# Altium schematic sheet Sheet1.SchDoc
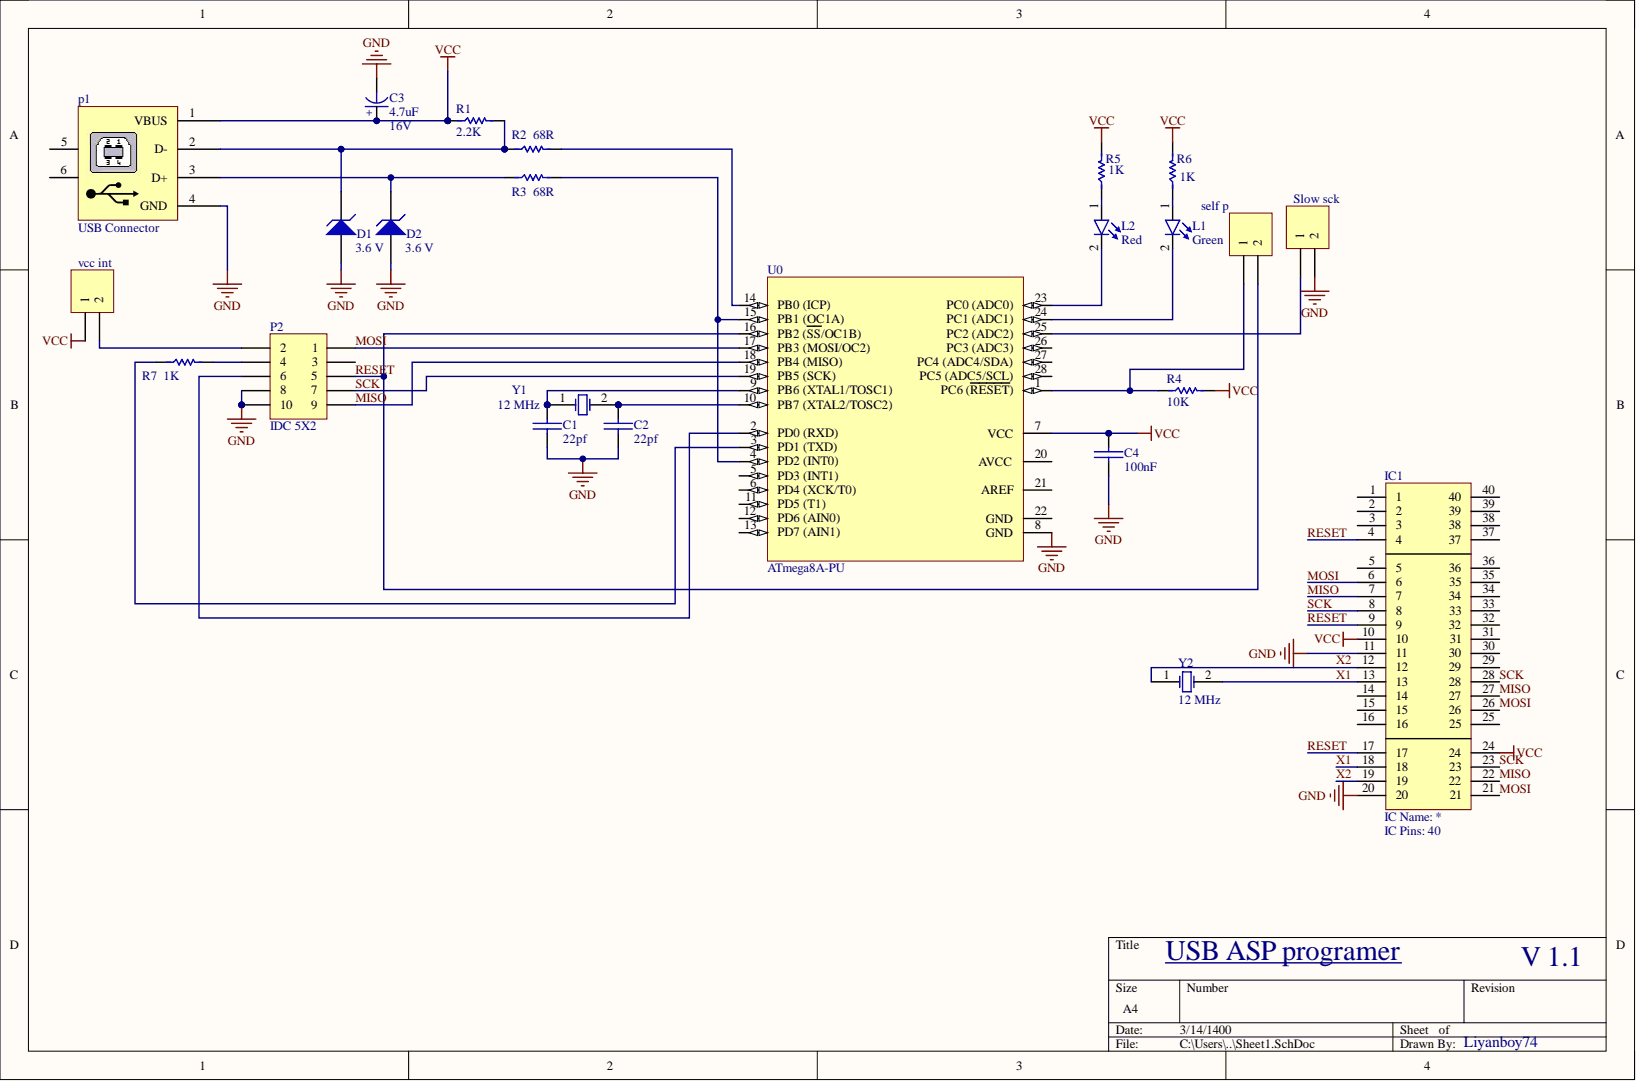
[diagram p0: Sheet1.SchDoc]

## Parts
C1  22pf  [Cap - 100nF]
C2  22pf  [Cap - 100nF]
C3  4.7uF  Voltage: 16V  [cap 03]
C4  100nF  [Cap - 100nF]
D1  Diode Zener  [Diode 1W]  (value not drawn)
D2  Diode Zener  [Diode 1W]  (value not drawn)
IC1  Zip Socket  [DIP40 - Zip Socket]  (value not drawn)
L1  Green  [LED-5mm]
L2  Red  [LED-5mm]
p1  USB Connector  [USB2.5-2H4E]
P2  IDC 5X2  [IDC2.54-H10C]
R1  2.2K  [Res-(1/4)]
R2  68R  [Res-(1/4)]
R3  68R  [Res-(1/4)]
R4  10K  [Res-(1/4)]
R5  1K  [Res-(1/4)]
R6  1K  [Res-(1/4)]
R7  1K  [Res-(1/4)]
self_p  Pin Header  [Jumper Small - 2.54 - BLUE]  (value not drawn)
Slow_sck  Pin Header  [Jumper Small - 2.54 - BLUE]  (value not drawn)
U0  ATmega8A-PU  [28P3]
vcc_int  Pin Header  [Jumper Small - 2.54 - BLUE]  (value not drawn)
Y1  12 MHz  [XTAL Small]
Y2  12 MHz  [XTAL Small]

## Nets
GND: C1.2 C2.2 C3.2 C4.2 D1.1 D2.1 IC1.11 IC1.20 p1.4(GND) P2.8 P2.10 Slow_sck.2 U0.8(GND)
VCC: C3.1 C4.1 IC1.10 IC1.24 p1.1(VBUS) R1.1 R4.2 R5.2 R6.2 U0.7(VCC) vcc_int.1
MISO: IC1.7 IC1.22 IC1.27 P2.9 U0.18(PB4_(MISO))
MOSI: IC1.6 IC1.21 IC1.26 P2.1 U0.17(PB3_(MOSI/OC2))
RESET: IC1.4 IC1.9 IC1.17 P2.5 self_p.2 U0.16(PB2_(SS/OC1B))
SCK: IC1.8 IC1.23 IC1.28 P2.7 U0.19(PB5_(SCK))
X1: IC1.13 IC1.18 Y2.2
X2: IC1.12 IC1.19 Y2.1
NetC1_1: C1.1 U0.9(PB6_(XTAL1/TOSC1)) Y1.1
NetC2_1: C2.1 U0.10(PB7_(XTAL2/TOSC2)) Y1.2
NetD1_2: D1.2 p1.2(D-) R1.2 R2.1
NetD2_2: D2.2 p1.3(D+) R3.1
NetL1_1: L1.1 R6.1
NetL1_2: L1.2 U0.24(PC1_(ADC1))
NetL2_1: L2.1 R5.1
NetL2_2: L2.2 U0.23(PC0_(ADC0))
Netp1_5: p1.5 P2.2 P2.3 P2.4 P2.6 R7.2 U0.2(PD0_(RXD)) vcc_int.2
NetR2_2: R2.2 U0.14(PB0_(ICP))
NetR3_2: R3.2 U0.4(PD2_(INT0)) U0.15(PB1_(OC1A))
NetR4_1: R4.1 self_p.1 U0.1(PC6_(RESET))
NetR7_1: R7.1 U0.3(PD1_(TXD))
NetSlow sck_1: Slow_sck.1 U0.25(PC2_(ADC2))

Not connected: IC1 1 2 3 5 14 15 16 25 29 30 31 32 33 34 35 36 37 38 39 40; p1 6; U0 5(PD3_(INT1)) 6(PD4_(XCK/T0)) 11(PD5_(T1)) 12(PD6_(AIN0)) 13(PD7_(AIN1)) 20(AVCC) 21(AREF) 22(GND) 26(PC3_(ADC3)) 27(PC4_(ADC4/SDA)) 28(PC5_(ADC5/SCL))

## Library data of the parts
C1 C2 C4 = Cap-Bipolar: Bipolar Capacitor; Designer: Saeid Jorablo; Organazation: ControTec; Voltage: 100V; Adding Date: 1387/12/20; Component Kind: Standard; Library Name: My Library.IntLib
  C1 C2: Value: 22pf
  C4: Value: 100nF
C3 = Cap-Polar: Polar Capacitor; Designer: Saeid Jorablo; Organazation: ControTec; Adding Date: 1387/12/20; Component Kind: Standard; Library Name: My Library.IntLib; Value: 4.7uF
D1 D2 = Diode Zener: 3.6 V; Company: E.P.I.L; Designer: Saeid Jorablo; Component Kind: Standard; Design Date: 2011/11/02; Library Name: My Library.IntLib; Voltage: 3.6 V
IC1 = Zip Socket: 40pin Zip/Zif Socket; Company: ECI CO.; IC Pins: 40; Adding Date: 11/25/2011; Component Kind: Standard; Library Name: My Library.IntLib
L1 L2 = LED: Designer: Saeid Jorablo; Organazation: ControTec; Adding Date: 1387/12/22; Component Kind: Standard; Description: LED; Library Name: My Library.IntLib; Size: SMD 1206
  L1: Color: Green
  L2: Color: Red
p1 = USB: USB Connector-B Type; Company: DASE Co; Designer: Eng. Saeid Jorablo; Adding Date: 10/26/2010; Component Kind: Standard; Library Name: My Library.IntLib
P2 = IDC 5X2: Header, 5-Pin, Dual row; Component Kind: Standard; LatestRevisionDate: 17-Jul-2002; LatestRevisionNote: Re-released for DXP Platform.; Library Name: My Library.IntLib; Signal Integrity: Connector; Publisher: Altium Limited
R1-R7 = Resistor: Power: 1/8 W; Tolerance: 5%; Component Kind: Standard; Description: Resistor; Library Name: My Library.IntLib; Simulation: Model Name
  R1: Value: 2.2K
  R2 R3: Value: 68R
  R4: Value: 10K
  R5-R7: Value: 1K
self_p Slow_sck vcc_int = CON2: 2x1; Component Kind: Standard; Library Name: AlmaLib1.IntLib
U0 = ATmega8A-PU: 8-bit AVR Microcontroller; Component Kind: Standard; ComponentLink1Description: Manufacturer Link; ComponentLink1URL: http://www.atmel.com/products/avr/default.asp?; ComponentLink2Description: ATmega8A Datasheet; ComponentLink2URL: http://www.atmel.com/dyn/resources/prod_documents/doc8159.pdf; ComponentLink3Description: ATmega8A Summary; ComponentLink3URL: http://www.atmel.com/dyn/resources/prod_documents/8159S.pdf; ComponentLink4Description: Atmel.com Home; ComponentLink4URL: http://www.atmel.com; DatasheetVersion: revC, Jul-2009; Library Name: Atmel Microcontroller 8-Bit megaAVR.IntLib; PackageDescription: 28-lead (0.300"/7.62mm Wide) Plastic Dual Inline Package (PDIP); PackageReference: 28P3; PackageVersion: revB, Sep-2001; Published: 1-Apr-2010; Supplier 1: Digi-Key; Supplier 2: Farnell; Supplier 3: Mouser; Supplier Part Number 1: ATMEGA8A-PU-ND; Supplier Part Number 2: 1748534; Supplier Part Number 3: 556-ATMEGA8A-PU; Publisher: Altium Limited
Y1 Y2 = XTAL: XTAL Crystal; Company: E.P.I.L; Component Kind: Standard; Design Date: 11/04/2011; Library Name: My Library.IntLib; Value: 12 MHz
